# Altium schematic sheet TRIFASE-CPU.SchDoc(TRIFASE-CPU)
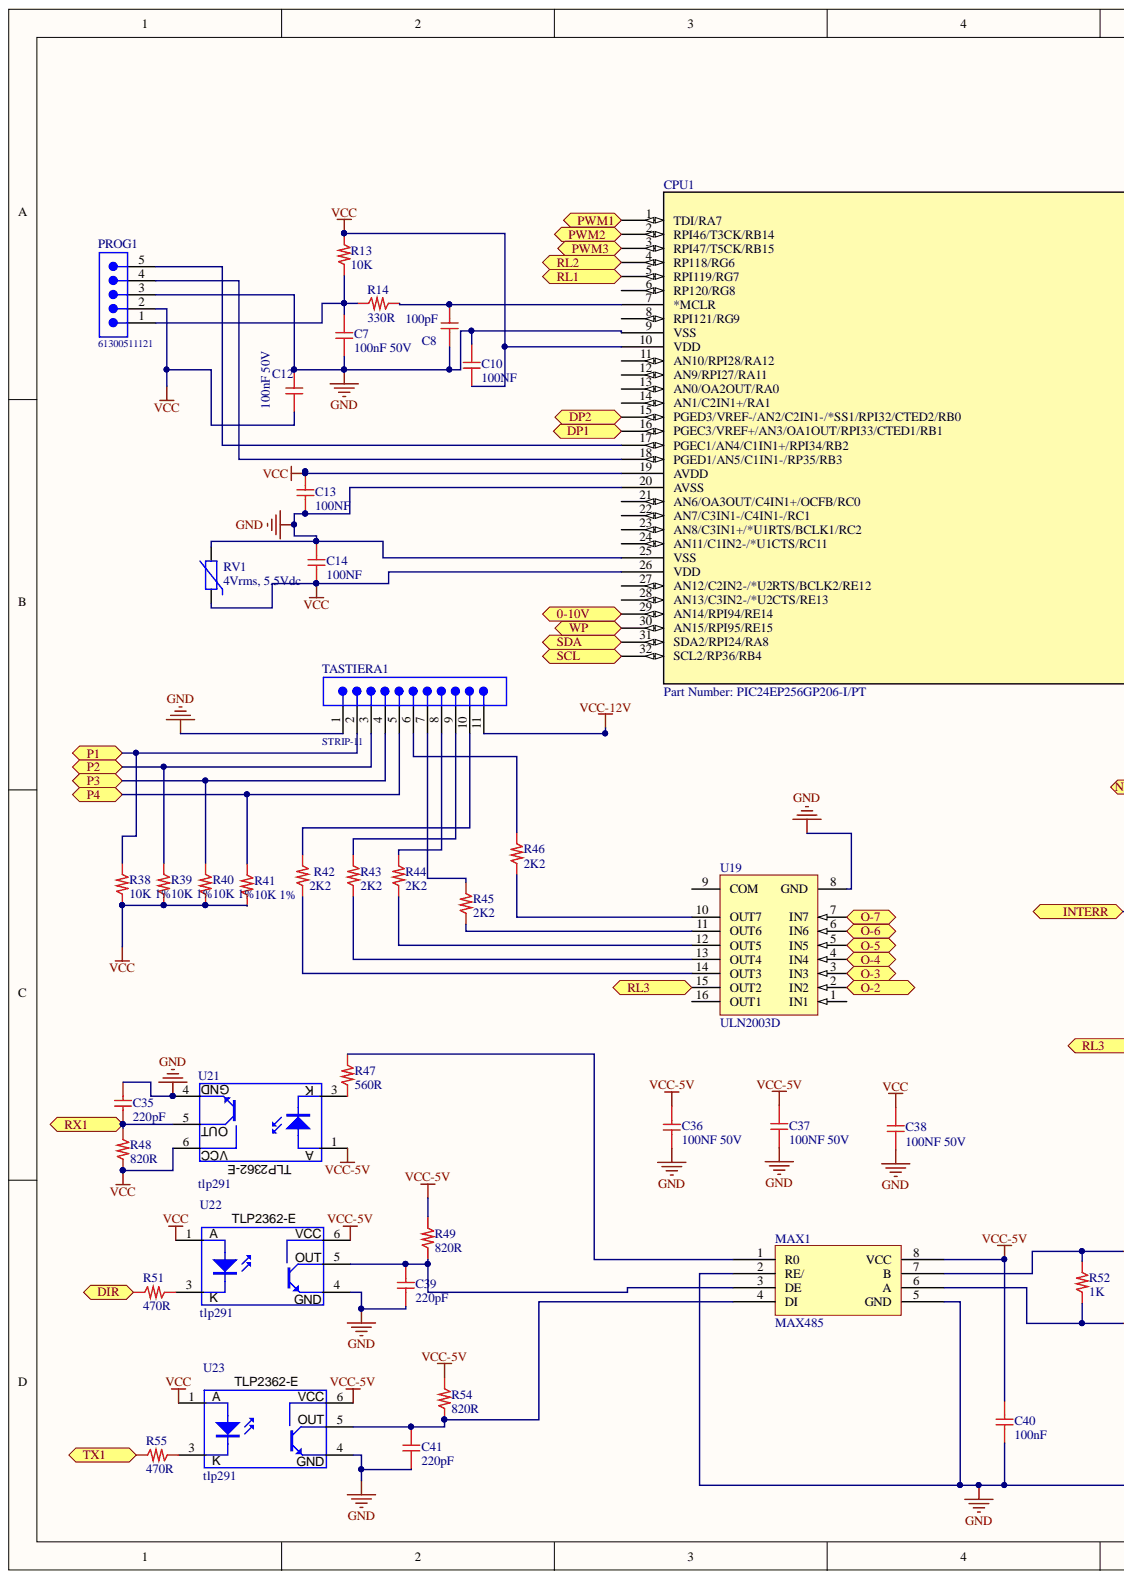
[diagram p0: TRIFASE-CPU.SchDoc, left]
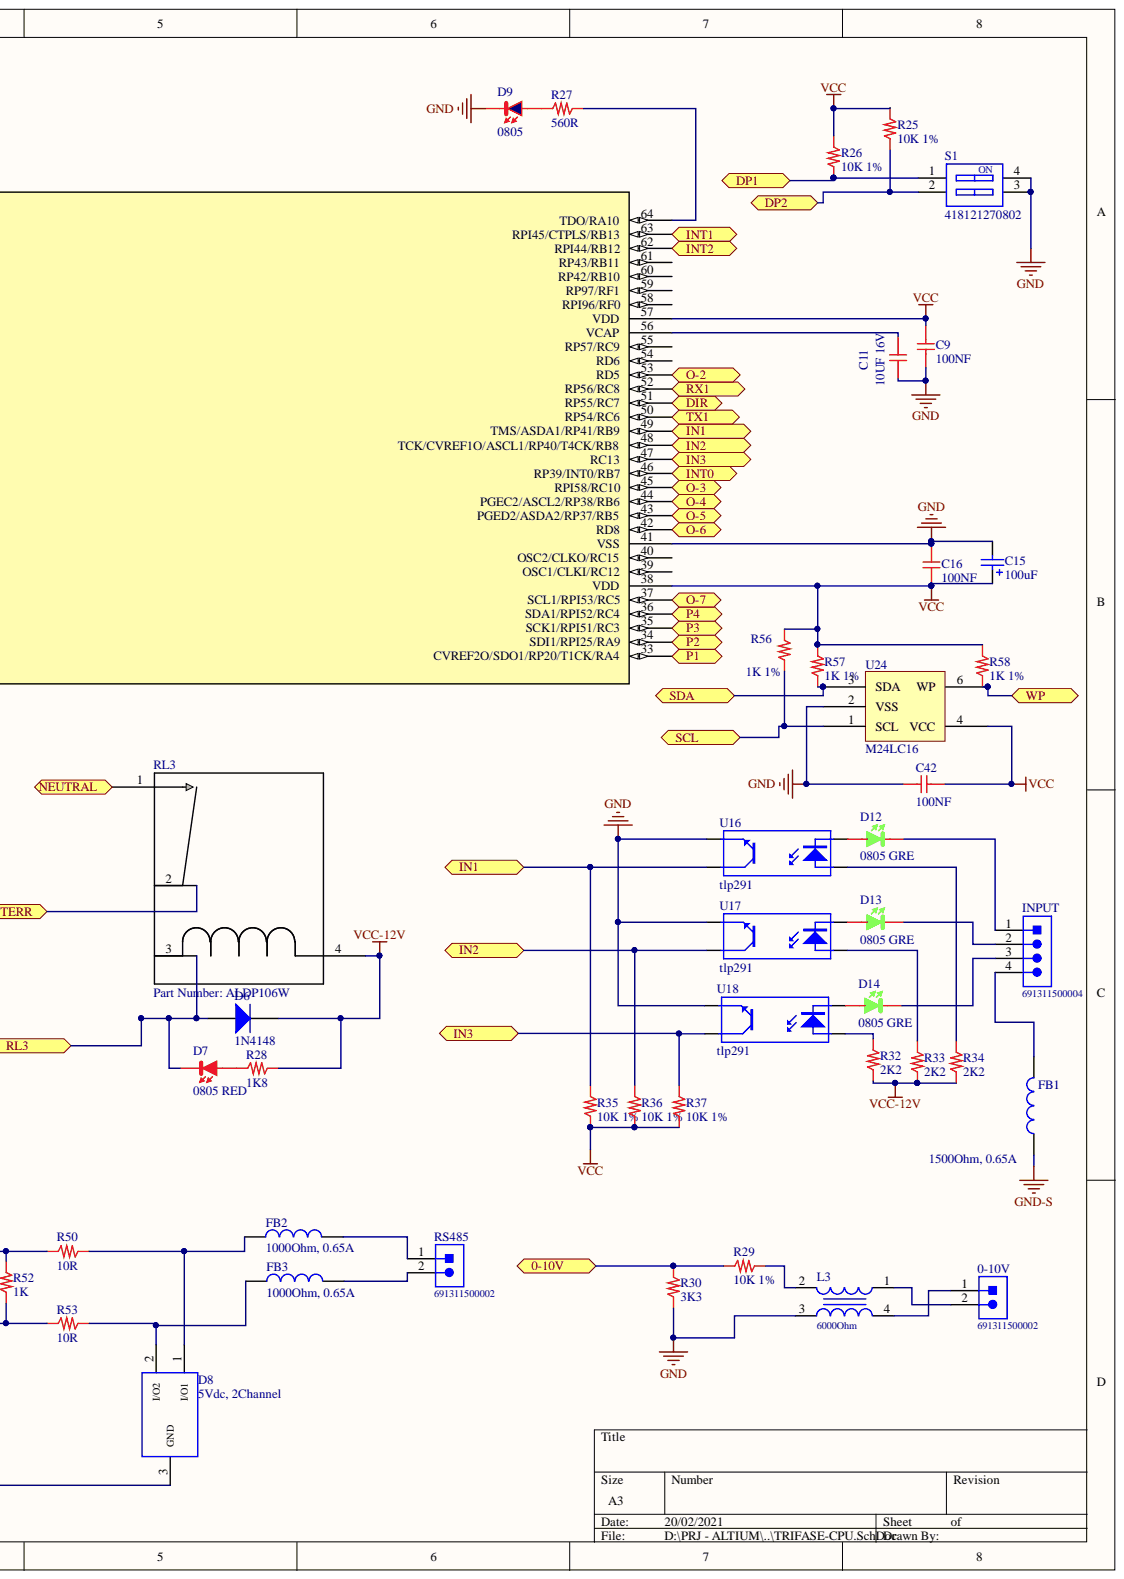
[diagram p1: TRIFASE-CPU.SchDoc, right]

## Parts
0-10V  691311500002  [691311500002]
C7  100nF 50V  [0603_c]
C8  100pF  [0603_c]
C9  100NF  [0402_C]
C10  100NF  [0402_C]
C11  10UF 16V  [0805_C]
C12  100nF 50V  [0603_c]
C13  100NF  [0402_C]
C14  100NF  [0402_C]
C15  value 100uF  [WCAP-ASLL_6.3x5.5]  (hidden comment: 865060143004)
C16  100NF  [0402_C]
C35  220pF  [0402_C]
C36  100NF 50V  [0603_c]
C37  100NF 50V  [0603_c]
C38  100NF 50V  [0603_c]
C39  220pF  [0402_C]
C40  100nF  [0805_C]
C41  220pF  [0402_C]
C42  100NF  [0402_C]
CPU1  Part Number: PIC24EP256GP206-I/PT  [TQFP64_10X10MC]  (value not drawn)
D6  1N4148  [1N4148]
D7  0805 RED  [0805_Red]
D8  5Vdc, 2Channel  [WE-TVS_Standard_SOT23-3L]
D9  0805  [0805-BLU]
D12  0805 GRE  [0805_Green]
D13  0805 GRE  [0805_Green]
D14  0805 GRE  [0805_Green]
FB1  1500Ohm, 0.65A  Rated Current: 0.65A  [WE-CBF_0603_Wide Band]
FB2  1000Ohm, 0.65A  Rated Current: 0.65A  [WE-CBF_0603_Wide Band]
FB3  1000Ohm, 0.65A  Rated Current: 0.65A  [WE-CBF_0603_Wide Band]
INPUT  691311500004  [691311500004]
L3  6000Ohm  [WE-CNSW_1206]
MAX1  MAX485  [SO-8]
PROG1  61300511121  [61300511121]
R13  10K  [0603_R]
R14  330R  [0603_R]
R25  10K 1%  [0603_R]
R26  10K 1%  [0603_R]
R27  560R  [0603_R]
R28  1K8  [0805_R]
R29  10K 1%  [0603_R]
R30  3K3  [0603_R]
R32  2K2  [1206_R]
R33  2K2  [1206_R]
R34  2K2  [1206_R]
R35  10K 1%  [0603_R]
R36  10K 1%  [0603_R]
R37  10K 1%  [0603_R]
R38  10K 1%  [0603_R]
R39  10K 1%  [0603_R]
R40  10K 1%  [0603_R]
R41  10K 1%  [0603_R]
R42  2K2  [1206_R]
R43  2K2  [1206_R]
R44  2K2  [1206_R]
R45  2K2  [1206_R]
R46  2K2  [1206_R]
R47  560R  [0603_R]
R48  820R  [0402_R]
R49  820R  [0402_R]
R50  10R  [0805_R]
R51  470R  [0603_R]
R52  1K  [0805_R]
R53  10R  [0805_R]
R54  820R  [0402_R]
R55  470R  [0603_R]
R56  1K 1%  [0603_R]
R57  1K 1%  [0603_R]
R58  1K 1%  [0603_R]
RL3  Part Number: ALDP106W  [PAN_ALD]
RS485  691311500002  [691311500002]
RV1  4Vrms, 5.5Vdc  [WE-VS_0603]
S1  418121270802  [418121270802]
TASTIERA1  STRIP-11  [STRIP-11]
U16  tlp291  [SO4_N]
U17  tlp291  [SO4_N]
U18  tlp291  [SO4_N]
U19  ULN2003D  [SO16_N]
U21  tlp291  [TLP2362-E]
U22  tlp291  [TLP2362-E]
U23  tlp291  [TLP2362-E]
U24  M24LC16  [SOT23-5_N]

## Ports
0-10V, DIR, DP1, DP2, IN1, IN2, IN3, INT0, INT1, INT2, INTERR, NEUTRAL, O-2, O-3, O-4, O-5, O-6, O-7, P1, P2, P3, P4, PWM1, PWM2, PWM3, RL1, RL2, RL3, RX1, SCL, SDA, TX1, WP

## Nets
GND: C7.2 C8.2 C9.2 C10.1 C11.2 C12.1 C13.2 C14.1 C15.2 C16.1 C35.1 C36.2 C37.2 C38.2 C39.2 C40.2 C41.2 C42.1 CPU1.9(VSS) CPU1.20(AVSS) CPU1.25(VSS) CPU1.41(VSS) D8.3 D9.K L3.3 MAX1.2(RE/) MAX1.5(GND) PROG1.3 R30.2 RV1.2 S1.3 S1.4 TASTIERA1.1 U16.3 U17.3 U18.3 U19.8(GND) U21.4 U22.4 U23.4 U24.2(VSS)
GND-S: FB1.1
VCC: C9.1 C10.2 C12.2 C13.1 C14.2 C15.1 C16.2 C38.1 C42.2 CPU1.10(VDD) CPU1.19(AVDD) CPU1.26(VDD) CPU1.38(VDD) CPU1.57(VDD) PROG1.2 R13.1 R25.1 R26.1 R35.2 R36.2 R37.2 R38.2 R39.2 R40.2 R41.2 R48.2 R56.1 R57.1 R58.1 RV1.1 U21.6(VCC) U22.1 U23.1 U24.4(VCC)
VCC-5V: C36.1 C37.1 C40.1 MAX1.8(VCC) R49.1 R54.1 U21.1(A) U22.6 U23.6
VCC-12V: D6.2 R28.2 R32.1 R33.1 R34.1 RL3.4 TASTIERA1.11
0-10V: CPU1.29(AN14/RPI94/RE14) R29.1 R30.1 ; port 0-10V
DIR: CPU1.51(RP55/RC7) R51.1 ; port DIR
DP1: CPU1.16(PGEC3/VREF+/AN3/OA1OUT/RPI33/CTED1/RB1) R26.2 S1.1 ; port DP1
DP2: CPU1.15(PGED3/VREF-/AN2/C2IN1-/*SS1/RPI32/CTED2/RB0) R25.2 S1.2 ; port DP2
IN1: CPU1.49(TMS/ASDA1/RP41/RB9) R35.1 U16.4 ; port IN1
IN2: CPU1.48(TCK/CVREF1O/ASCL1/RP40/T4CK/RB8) R36.1 U17.4 ; port IN2
IN3: CPU1.47(RC13) R37.1 U18.4 ; port IN3
INT0: CPU1.46(RP39/INT0/RB7) ; port INT0
INT1: CPU1.63(RPI45/CTPLS/RB13) ; port INT1
INT2: CPU1.62(RPI44/RB12) ; port INT2
INTERR: RL3.2 ; port INTERR
NEUTRAL: RL3.1 ; port NEUTRAL
O-2: CPU1.53(RD5) U19.2(IN2) ; port O-2
O-3: CPU1.45(RPI58/RC10) U19.3(IN3) ; port O-3
O-4: CPU1.44(PGEC2/ASCL2/RP38/RB6) U19.4(IN4) ; port O-4
O-5: CPU1.43(PGED2/ASDA2/RP37/RB5) U19.5(IN5) ; port O-5
O-6: CPU1.42(RD8) U19.6(IN6) ; port O-6
O-7: CPU1.37(SCL1/RPI53/RC5) U19.7(IN7) ; port O-7
P1: CPU1.33(CVREF2O/SDO1/RP20/T1CK/RA4) R38.1 TASTIERA1.2 ; port P1
P2: CPU1.34(SDI1/RPI25/RA9) R39.1 TASTIERA1.3 ; port P2
P3: CPU1.35(SCK1/RPI51/RC3) R40.1 TASTIERA1.4 ; port P3
P4: CPU1.36(SDA1/RPI52/RC4) R41.1 TASTIERA1.5 ; port P4
PWM1: CPU1.1(TDI/RA7) ; port PWM1
PWM2: CPU1.2(RPI46/T3CK/RB14) ; port PWM2
PWM3: CPU1.3(RPI47/T5CK/RB15) ; port PWM3
RL1: CPU1.5(RPI119/RG7) ; port RL1
RL2: CPU1.4(RP118/RG6) ; port RL2
RL3: D6.1 D7.K RL3.3 U19.15(OUT2) ; port RL3
RX1: C35.2 CPU1.52(RP56/RC8) R48.1 U21.5 ; port RX1
SCL: CPU1.32(SCL2/RP36/RB4) R56.2 U24.1(SCL) ; port SCL
SDA: CPU1.31(SDA2/RPI24/RA8) R57.2 U24.3(SDA) ; port SDA
TX1: CPU1.50(RP54/RC6) R55.1 ; port TX1
WP: CPU1.30(AN15/RPI95/RE15) R58.2 U24.6(WP) ; port WP
Net0-10V_1: 0-10V.1 L3.4
Net0-10V_2: 0-10V.2 L3.1
NetC7_1: C7.1 PROG1.1 R13.2 R14.1
NetC8_1: C8.1 CPU1.7(*MCLR) R14.2
NetC11_1: C11.1 CPU1.56(VCAP)
NetC39_1: C39.1 MAX1.3(DE) R49.2 U22.5
NetC41_1: C41.1 MAX1.4(DI) R54.2 U23.5
NetCPU1_17: CPU1.17(PGEC1/AN4/C1IN1+/RPI34/RB2) PROG1.5
NetCPU1_18: CPU1.18(PGED1/AN5/C1IN1-/RP35/RB3) PROG1.4
NetCPU1_64: CPU1.64(TDO/RA10) R27.2
NetD7_A: D7.A R28.1
NetD8_1: D8.1 FB2.1 R50.2
NetD8_2: D8.2 FB3.1 R53.2
NetD9_A: D9.A R27.1
NetD12_A: D12.A U16.2
NetD12_K: D12.K INPUT.1
NetD13_A: D13.A U17.2
NetD13_K: D13.K INPUT.2
NetD14_A: D14.A U18.2
NetD14_K: D14.K INPUT.3
NetFB1_2: FB1.2 INPUT.4
NetFB2_2: FB2.2 RS485.1
NetFB3_2: FB3.2 RS485.2
NetL3_2: L3.2 R29.2
NetMAX1_1: MAX1.1(R0) R47.2
NetMAX1_6: MAX1.6(A) R52.1 R53.1
NetMAX1_7: MAX1.7(B) R50.1 R52.2
NetR32_2: R32.2 U18.1
NetR33_2: R33.2 U17.1
NetR34_2: R34.2 U16.1
NetR42_1: R42.1 U19.14(OUT3)
NetR42_2: R42.2 TASTIERA1.10
NetR43_1: R43.1 U19.13(OUT4)
NetR43_2: R43.2 TASTIERA1.9
NetR44_1: R44.1 U19.12(OUT5)
NetR44_2: R44.2 TASTIERA1.8
NetR45_1: R45.1 U19.11(OUT6)
NetR45_2: R45.2 TASTIERA1.7
NetR46_1: R46.1 U19.10(OUT7)
NetR46_2: R46.2 TASTIERA1.6
NetR47_1: R47.1 U21.3
NetR51_2: R51.2 U22.3
NetR55_2: R55.2 U23.3(K)

Not connected: CPU1 6(RP120/RG8) 8(RPI121/RG9) 11(AN10/RPI28/RA12) 12(AN9/RPI27/RA11) 13(AN0/OA2OUT/RA0) 14(AN1/C2IN1+/RA1) 21(AN6/OA3OUT/C4IN1+/OCFB/RC0) 22(AN7/C3IN1-/C4IN1-/RC1) 23(AN8/C3IN1+/*U1RTS/BCLK1/RC2) 24(AN11/C1IN2-/*U1CTS/RC11) 27(AN12/C2IN2-/*U2RTS/BCLK2/RE12) 28(AN13/C3IN2-/*U2CTS/RE13) 39(OSC1/CLKI/RC12) 40(OSC2/CLKO/RC15) 54(RD6) 55(RP57/RC9) 58(RPI96/RF0) 59(RP97/RF1) 60(RP42/RB10) 61(RP43/RB11); U19 1(IN1) 9(COM) 16(OUT1)

## Library data of the parts
0-10V RS485 = 691311500002: Serie 311 - 5.08mm Open Vertical PCB Header WR-TBL, 2 pin; Pin Number: 2; Gender: Male; Published Date: July 2018; Pitch: 5.08 mm; Part Number: 691311500002; Packaging: Box; Operating Temperature: -40 to 105 degC; Mounting Technology: THT; Library Name: Pluggable PCB System WR-TBL_5.08 mm.SchLib; ComponentLink2URL: http://katalog.we-online.de/em/datasheet/6913115000xx.pdf; ComponentLink2Description: Datasheet; ComponentLink1URL: http://www.we-online.de; ComponentLink1Description: Manufacturer; Component Kind: Standard; Publisher: Wurth Elektronik
C7 C12 C36-C38 = 100NF 50V: Cap X7R 100nF 50V Flex Terminal; Produttore: Samsung; Cod. Produttore: CL10B104KB8SFNC; Cod. Interno: COCS50665050104; Library Name: CapSMD0603.SchLib; Component Kind: Standard; Cod. DigiKey: 1276-1936-2-ND
C8 = 100pF 50V: Cap X7R 100pF 50V; Produttore: ??; Cod. Interno: COCS??; Library Name: CapSMD0603.SchLib; Component Kind: Standard; Cod. Produttore: ??
C9 C10 C13 C14 C16 C42 = 100NF 16V: Cap X7R 100NF 16V; Produttore: ??; Cod. Interno: COCS556561600104; Library Name: CapSMD0402.SchLib; Component Kind: Standard; Cod. Produttore: ??
C11 = 10UF 16V: Cap X5R 10UF 16V; Produttore: TDK; Cod. Produttore: C2012X6S1C106K125AC; Cod. Interno: COCS517061600106; Temperature Range: Da -55°C a +105°C; Library Name: CapSMD0805.SchLib; Component Kind: Standard; Cod. DigiKey: 445-7611-2-ND
C15 = 865060143004: WCAP-ASLL Aluminum Electrolytic Capacitors, size Ф6.3x5.5mm, 6.3V DC, 100uF; Rated Voltage: 6.3V DC; Size: Ф6.3x5.5mm; Published Date: June 2017; Part Number: 865060143004; Operating Temperature: -55 °C up to +105 °C; Life Cycle: 2000h; Library Name: WCAP-ASLL.SchLib; ComponentLink2URL: http://katalog.we-online.de/pbs/datasheet/865060143004.pdf; ComponentLink2Description: Datasheet; ComponentLink1URL: http://www.we-online.de; ComponentLink1Description: Manufacturer; Component Kind: Standard; Publisher: Wurth Elektronik
C35 C39 C41 = 220pF 50V: Cap COG 220pF 50V; Produttore: ??; Cod. Interno: COCS506565000221; Library Name: CapSMD0402.SchLib; Component Kind: Standard; Cod. Produttore: ??
C40 = 100nF 100V: Cap X7R 100nF 100V; Produttore: ??; Cod. Interno: COCS 557051010104; Library Name: CapSMD0805.SchLib; Component Kind: Standard; Cod. Produttore: ??
CPU1 = PIC24EP256GP206-: No Description Available; Vendor: Microchip; Built_By: EMA_UL_Team; Value: Value; Type: Type; RefDes: RefDes; Manufacturer_Part_Number: PIC24EP256GP206-I/PT; Library Name: PIC24EP256GP206.SchLib; Component Kind: Standard; Copyright: Copyright (C) 2018 Accelerated Designs. All rights reserved
D6 = 1N4148: High Conductance Fast Diode; Published: 8-Jun-2000; PackageReference: DO-35; PackageDescription: Diode, Axial; Body 3.8 x 1.9 mm (LxDia. max), Lead Dia. 0.53 mm (max); LatestRevisionNote: Re-released for DXP Platform.; LatestRevisionDate: 17-Jul-2002; DatasheetVersion: 1997; ComponentLink2URL: http://www.fairchildsemi.com/ds/1N%2F1N4148.pdf; ComponentLink2Description: Datasheet; ComponentLink1URL: http://www.fairchildsemi.com/; ComponentLink1Description: Manufacturer Link; Component Kind: Standard; Code_JEDEC: DO-204-AH; Publisher: Altium Limited; Library Name: HSW.SchLib
D7 = LED_ROSSO_0805: LED Rosso 0805; V: 2.0V; Produttore: WE; Luminosità: 150mcd; Cod. Produttore: 150080RS75000; Cod. Interno: LEDS 2AC080RS7500; Component Kind: Standard; Library Name: DiodiLED.SchLib
D8 = 824022: WE-TVS TVS Diode - Standard Series, SOT23-3L, 5Vdc, 2 Channel; Reverse Peak Pulse Current: 8A; Reverse Channel Leakage Current: 2.5uA; Reverse Breakdown Voltage min.: 6.1V; DC Operating Voltage: 5V; Channel Input Capacitance: 12pF; Channel: 2; Size: SOT23-3L; Published Date: June 2019; Part Number: 824022; Packaging: Tape and Reel; Operating Temperature: -55 to +125degC; Mounting Technology: SMT; Library Name: WE-TVS.SchLib; ComponentLink2URL: https://katalog.we-online.com/pbs/datasheet/824022.pdf; ComponentLink2Description: Datasheet; ComponentLink1URL: http://www.we-online.de; ComponentLink1Description: Manufacturer; Component Kind: Standard; Publisher: Wurth Elektronik
D9 = LED BLU 0805: Vf(typ): 3.3V; Produttore: kingbright; Luminosità: 100mcd 20mA; Cod. Produttore: KPTR-3216QBC-D; Description: LED BLU 0805; Component Kind: Standard; Library Name: DiodiLED.SchLib
D12-D14 = LED_Verde_0805: LED Verde 0805; V: 3.1V; Produttore: WE; Luminosità: 450mcd; Cod. Produttore: 150080GS75000; Cod. Interno: LEDS 9AC080GS7500; Component Kind: Standard; Library Name: DiodiLED.SchLib
FB1 = 742792691: WE-CBF SMT EMI Suppression Ferrite Bead, size 0603, 1500Ohm, 0.65A; Type: Wide Band; Impedance max.: 1900Ohm; DC Resistance max.: 0.7Ohm; Size: 0603; Published Date: March 2019; Part Number: 742792691; Packaging: Tape and Reel; Operating Temperature: -55 to +125degC; Mounting Technology: SMT; Library Name: WE-CBF_0603.SchLib; Impedance@100 MHz: 1500Ohm; ComponentLink2URL: https://katalog.we-online.com/pbs/datasheet/742792691.pdf; ComponentLink2Description: Datasheet; ComponentLink1URL: http://www.we-online.de; ComponentLink1Description: Manufacturer; Component Kind: Standard; Publisher: Wurth Elektronik
FB2 FB3 = 74279266: WE-CBF SMT EMI Suppression Ferrite Bead, size 0603, 1000Ohm, 0.65A; Type: Wide Band; Impedance max.: 1350Ohm; DC Resistance max.: 0.6Ohm; Size: 0603; Published Date: March 2019; Part Number: 74279266; Packaging: Tape and Reel; Operating Temperature: -55 to +125degC; Mounting Technology: SMT; Library Name: WE-CBF_0603.SchLib; Impedance@100 MHz: 1000Ohm; ComponentLink2URL: https://katalog.we-online.com/pbs/datasheet/74279266.pdf; ComponentLink2Description: Datasheet; ComponentLink1URL: http://www.we-online.de; ComponentLink1Description: Manufacturer; Component Kind: Standard; Publisher: Wurth Elektronik
INPUT = 691311500004: Serie 311 - 5.08mm Open Vertical PCB Header WR-TBL, 4 pin; Pin Number: 4; Gender: Male; Published Date: July 2018; Pitch: 5.08 mm; Part Number: 691311500004; Packaging: Box; Operating Temperature: -40 to 105 degC; Mounting Technology: THT; Library Name: Pluggable PCB System WR-TBL_5.08 mm.SchLib; ComponentLink2URL: http://katalog.we-online.de/em/datasheet/6913115000xx.pdf; ComponentLink2Description: Datasheet; ComponentLink1URL: http://www.we-online.de; ComponentLink1Description: Manufacturer; Component Kind: Standard; Publisher: Wurth Elektronik
L3 = 744232101: WE-CNSW SMT Common Mode Line Filter, size 1206, 6000Ohm, 0.09A, 50V; Manufacturer: Wurth Elektronik; Winding Style: bifiliar; Insulation Test Voltage: 125VAC; Impedance Test Condition: 100MHz; DC Resistance max.: 5.5Ohm; Size: 1206; Rated Voltage: 50V; Rated Current: 0.09A; Published Date: April 2020; Packaging: Tape and Reel; Operating Temperature: -40 to +125degC; Mounting Technology: SMT; Manufacturer Part Number: 744232101; Library Name: WE-CNSW_1206.SchLib; Impedance: 6000Ohm; ComponentLink2URL: https://www.we-online.com/catalog/datasheet/744232101.pdf; ComponentLink2Description: Datasheet; ComponentLink1URL: http://www.we-online.de; ComponentLink1Description: Manufacturer; Component Kind: Standard
PROG1 = 61300511121: THT Vertical Pin Header WR-PHD, Pitch 2.54 mm, Single Row, 5 pins; Working Voltage: 250 VAC; Withstanding Voltage: 500 VAC; Rated Current: 3 A; Pitch: 2.54 mm; Pin Number: 5; Gender: Male; Contact Resistance: 20 mOhm; Row Number: Single; Published Date: August 2018; Part Number: 61300511121; Packaging: Bag; Orientation: Vertical; Operating Temperature: -40 to 105 degC; Mounting Technology: THT; Library Name: Pin Header WR-PHD_2.54 mm.SchLib; ComponentLink2URL: https://katalog.we-online.de/em/datasheet/6130xx11121.pdf; ComponentLink2Description: Datasheet; ComponentLink1URL: http://www.we-online.de; ComponentLink1Description: Manufacturer; Component Kind: Standard; Publisher: Wurth Elektronik
R13 = 2K7: Res Film Spesso 1/16W 2K7 5%; Produttore: VISHAY; Cod. Produttore: CRCW06032K70JNEA; Cod. Interno: RESS4166556B0272; Library Name: ResSMD0603.SchLib; Component Kind: Standard
R14 = 330R: Res Film Spesso 1/16W 330R 5%; Cod. Interno: RESS4166556B0331; Library Name: ResSMD0603.SchLib; Component Kind: Standard
R25 R26 R29 R35-R41 = 10K 1%: Res Film Spesso 1/16W 10K 1%; Produttore: Panasonic; Cod. Produttore: ERJ-3EKF1002V; Cod. Interno: RESS4166356B0103; Library Name: ResSMD0603.SchLib; Component Kind: Standard
R27 R47 = 560R: Res Film Spesso 1/16W 560R 5%; Cod. Interno: RESS 4166556B0561; Library Name: ResSMD0603.SchLib; Component Kind: Standard
R28 = 1K8: Res Film Spesso 1/2W 1K8 5%; Potenza: 1/2W 5%; Cod. Interno: RESS4170555B0182??; Library Name: ResSMD0805.SchLib; Component Kind: Standard
R30 = 3K3: Res Film Spesso 1/16W 3K3 5%; Produttore: ??; Cod. Interno: RESS4166556B0332; Library Name: ResSMD0603.SchLib; Component Kind: Standard; Cod. Produttore: ??
R32-R34 R44-R46 = 2K2: 2K2 1206 1/4W; Library Name: ResSMD1206.SchLib; Component Kind: Standard; Case: 1206
R42 R43 = 1K8: 1K8 1206 1/4W; Library Name: ResSMD1206.SchLib; Component Kind: Standard; Case: 1206
R48 R49 R54 = 820R: Res Film Spesso 1/16W 820R 5%; Produttore: ??; Cod. Interno: RESS4166560C0121; Library Name: ResSMD0402.SchLib; Component Kind: Standard; Cod. Produttore: ??
R50 R53 = 10R: Res Film Spesso 1/8W 10R 5%; Potenza: 1/8W 5%; Cod. Interno: RESS4170555B0100; Library Name: ResSMD0805.SchLib; Component Kind: Standard
R51 R55 = 470R: Res Film Spesso 1/16W 470R 5%; Produttore: ??; Cod. Interno: RESS4166556B0471??; Library Name: ResSMD0603.SchLib; Component Kind: Standard; Cod. Produttore: ??
R52 = 1K: Res Film Spesso 1/2W 1K 5%; Potenza: 1/2W 5%; Cod. Interno: RESS4170555B0122??; Library Name: ResSMD0805.SchLib; Component Kind: Standard
R56-R58 = 1K 1%: Res Film Spesso 1/16W 1K 1%; Produttore: ??; Cod. Interno: RESS4166356B0102; Library Name: ResSMD0603.SchLib; Component Kind: Standard; Cod. Produttore: ??
RL3 = ALDP106W: No Description Available; Vendor: Panasonic; Built_By: EMA_UL_Team; Value: Value; Type: DEV; RefDes: RefDes; Manufacturer_Part_Number: ALDP106W; Component Kind: Standard; Library Name: FTR-F3.SchLib; Copyright: Copyright (C) 2018 Accelerated Designs. All rights reserved
RV1 = 82536040: WE-VS SMD Varistor, size 0603, 4Vrms, 5.5Vdc; Withstanding Surge Current: 30A; Varistor Voltage: 8V; Energy Dissipation: 0.1J; Clamping Voltage: 21V; Size: 0603; Published Date: March 2019; Part Number: 82536040; Packaging: Tape and Reel; Operating Temperature: -40 to +85degC; Mounting Technology: SMT; Library Name: WE-VS_0603.SchLib; DC Operating Voltage: 5.5Vdc; ComponentLink2URL: https://katalog.we-online.com/pbs/datasheet/82536040.pdf; ComponentLink2Description: Datasheet; ComponentLink1URL: http://www.we-online.de; ComponentLink1Description: Manufacturer; Component Kind: Standard; AC Operating Voltage: 4Vrms; Publisher: Wurth Elektronik
S1 = 418121270802: SMD Dip Switch WS-DISV, Small Compact, Raised Actuator, pitch 2.54mm, 2pin; Pole Number: 2; Operation Force: 600g max.; Actuator Type: Raise Type; Published Date: April 2019; Pitch: 2.54mm; Part Number: 418121270802; Packaging: Tape and Reel; Operating Temperature: -40 to +85degC; Mounting Technology: SMT; ComponentLink2URL: https://katalog.we-online.com/em/datasheet/418121270802.pdf; ComponentLink2Description: Datasheet; ComponentLink1URL: http://www.we-online.de; ComponentLink1Description: Manufacturer; Component Kind: Standard; Publisher: Wurth Elektronik; Library Name: DIPSMD WS-DISV.SchLib
TASTIERA1 = STRIP-11: THT Vertical Pin Header WR-PHD, Pitch 2.54 mm, Single Row, 12 pins; Part Number: 61301211121; Working Voltage: 250 VAC; Withstanding Voltage: 500 VAC; Rated Current: 3 A; Pitch: 2.54 mm; Pin Number: 12; Gender: Male; Contact Resistance: 20 mOhm; Row Number: Single; Published Date: August 2018; Packaging: Bag; Orientation: Vertical; Operating Temperature: -40 to 105 degC; Mounting Technology: THT; ComponentLink2URL: https://katalog.we-online.de/em/datasheet/6130xx11121.pdf; ComponentLink2Description: Datasheet; ComponentLink1URL: http://www.we-online.de; ComponentLink1Description: Manufacturer; Component Kind: Standard; Publisher: Wurth Elektronik; Library Name: HSW.SchLib
U16-U18 = tlp291: 4-Pin Phototransistor Optocoupler; Published: 24-Mar-1999; PackageReference: DIP-4; LatestRevisionNote: Sch pins 3 & 4 Swapped.; LatestRevisionDate: 04-Mar-2005; DatasheetVersion: Nov-2003; Component Kind: Standard; Publisher: Altium Limited; Library Name: HSW.SchLib
U19 = ULN2003D: Seven Darlington Array; Published: 8-Jun-2000; PackageVersion: May-1998; PackageReference: SO16; PackageDescription: 16-Pin Small Outline Integrated Circuit 1.27 mm Pitch; LatestRevisionNote: IPC-7351 Footprint Added.; LatestRevisionDate: 13-Apr-2006; DatasheetVersion: Sep-1998; ComponentLink2URL: http://www.st.com/stonline/products/literature/ds/5279/uln2001.pdf; ComponentLink2Description: Datasheet; ComponentLink1URL: http://www.st.com/; ComponentLink1Description: Manufacturer Link; Component Kind: Standard; Code_IPC: SOIC127P600-16; Publisher: Altium Limited; Library Name: HSW.SchLib
U21-U23 = TLP2362-E: 4-Pin Phototransistor Optocoupler; Published: 24-Mar-1999; PackageReference: DIP-4; LatestRevisionNote: Sch pins 3 & 4 Swapped.; LatestRevisionDate: 04-Mar-2005; DatasheetVersion: Nov-2003; Component Kind: Standard; Publisher: Altium Limited; Library Name: HSW.SchLib
MAX1 = MAX485: Component Kind: Standard; Library Name: HSW.SchLib
U24 = M24LC16: Component Kind: Standard; Library Name: HSW.SchLib
